# Altium schematic sheet jjfly_2.SchDoc
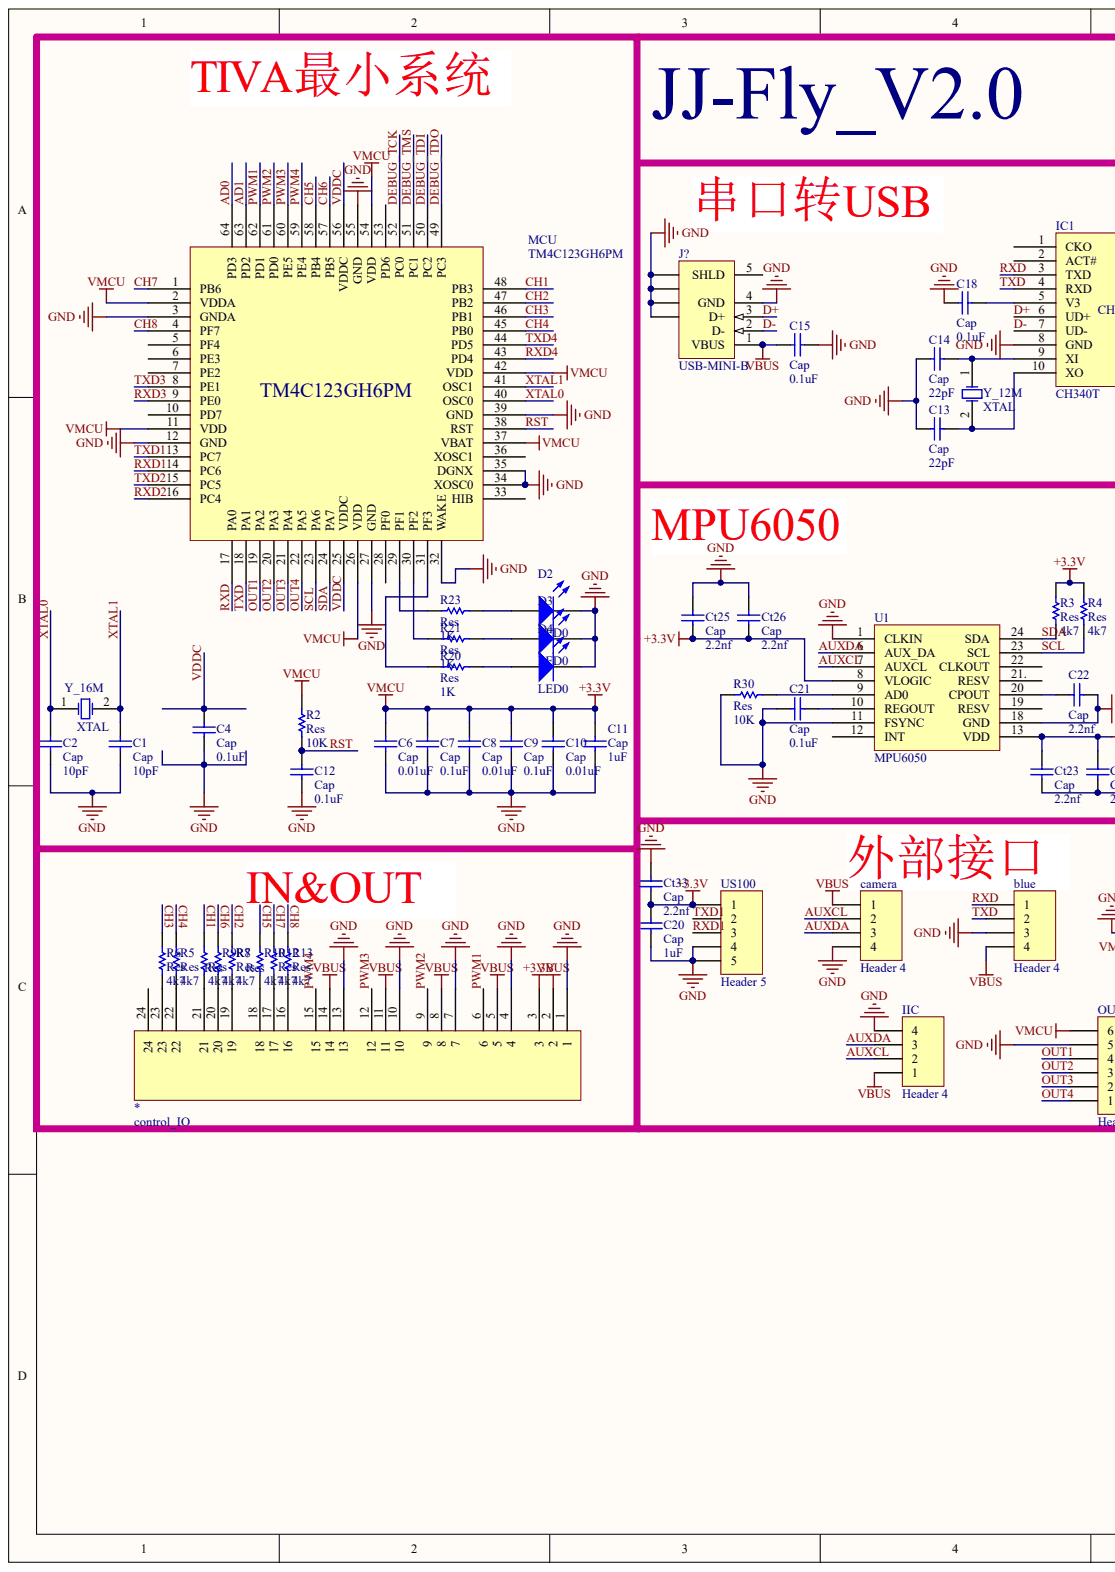
[diagram p0: jjfly_2.SchDoc, left]
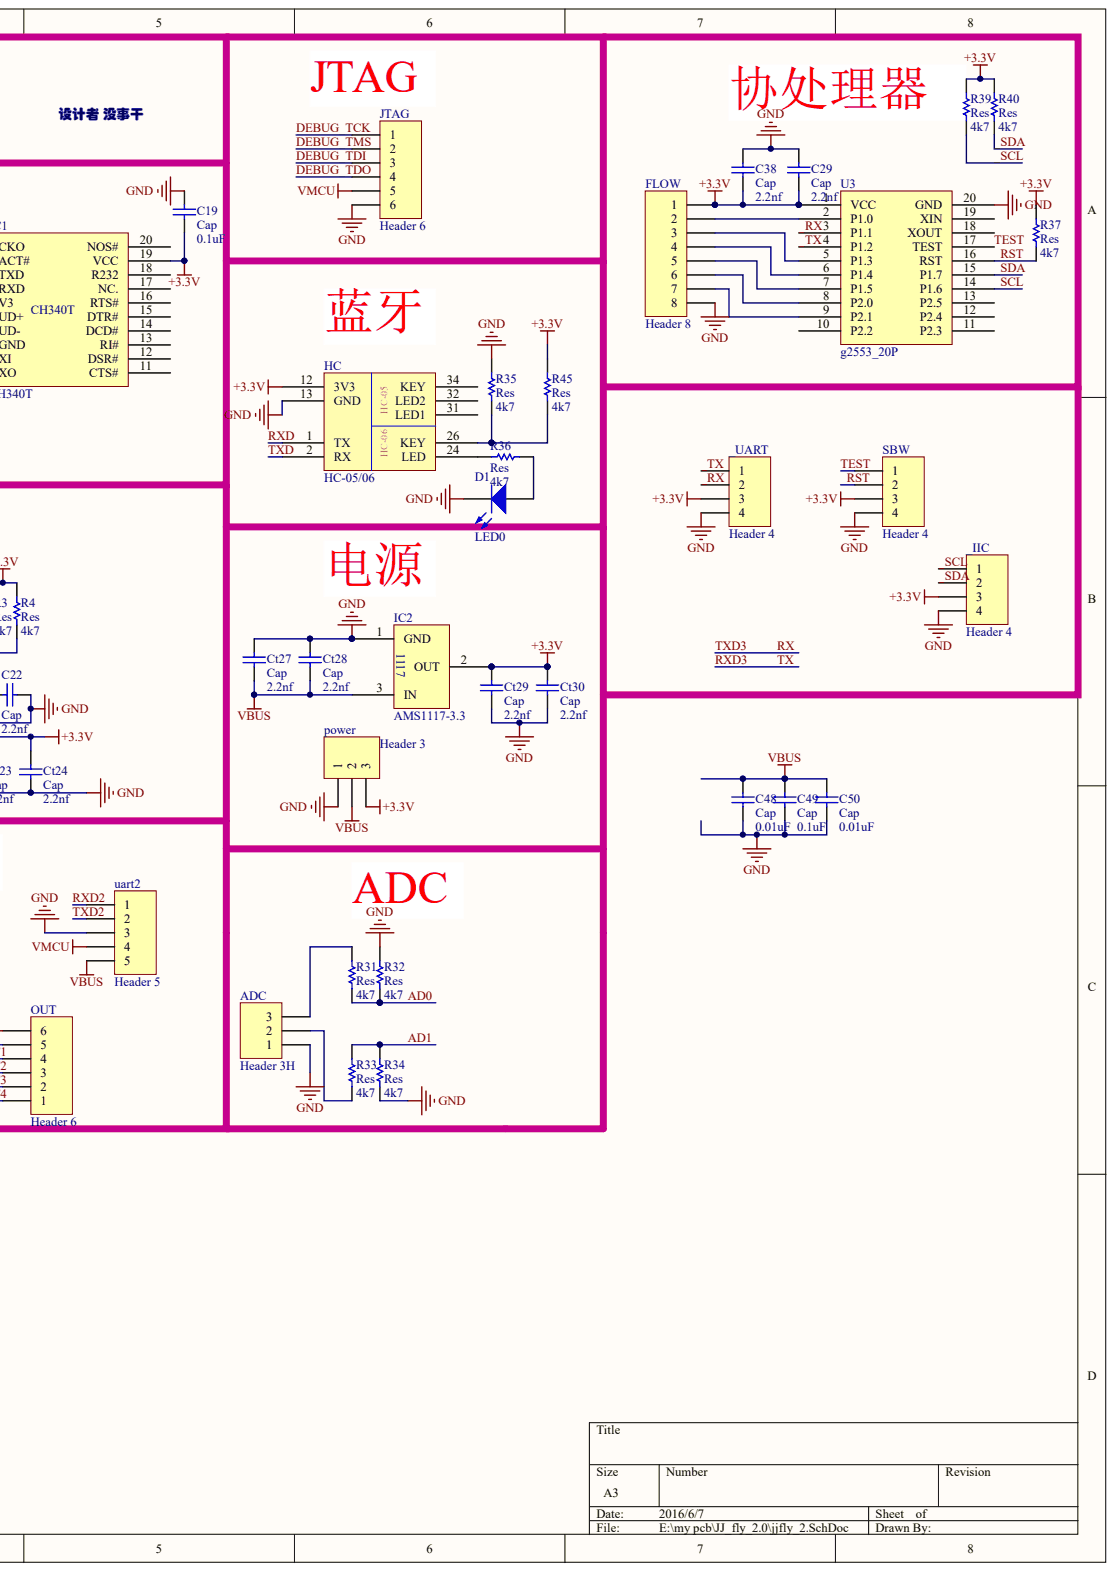
[diagram p1: jjfly_2.SchDoc, right]

## Parts
*  control_IO
_IIC  Header 4
_UART  Header 4
ADC  Header 3H
blue  Header 4
C1  Cap  value 10pF
C2  Cap  value 10pF
C4  Cap  value 0.1uF
C6  Cap  value 0.01uF
C7  Cap  value 0.1uF
C8  Cap  value 0.01uF
C9  Cap  value 0.1uF
C10  Cap  value 0.01uF
C11  Cap  value 1uF
C12  Cap  value 0.1uF
C13  Cap  value 22pF
C14  Cap  value 22pF
C15  Cap  value 0.1uF
C18  Cap  value 0.1uF
C19  Cap  value 0.1uF
C20  Cap  value 1uF
C21  Cap  value 0.1uF
C22  Cap  value 2.2nf
C29  Cap  value 2.2nf
C38  Cap  value 2.2nf
C48  Cap  value 0.01uF
C49  Cap  value 0.1uF
C50  Cap  value 0.01uF
camera  Header 4
Ct23  Cap  value 2.2nf
Ct24  Cap  value 2.2nf
Ct25  Cap  value 2.2nf
Ct26  Cap  value 2.2nf
Ct27  Cap  value 2.2nf
Ct28  Cap  value 2.2nf
Ct29  Cap  value 2.2nf
Ct30  Cap  value 2.2nf
Ct33  Cap  value 2.2nf
D1  LED0
D2  LED0
D3  LED0
D4  LED0
FLOW  Header 8
HC  HC-05/06
IC1  CH340T
IC2  AMS1117-3.3
IIC  Header 4
J?  USB-MINI-B
JTAG  Header 6
MCU  TM4C123GH6PM
OUT  Header 6
power  Header 3
R2  Res  value 10K
R3  Res  value 4k7
R4  Res  value 4k7
R5  Res  value 4k7
R6  Res  value 4k7
R7  Res  value 4k7
R8  Res  value 4k7
R9  Res  value 4k7
R10  Res  value 4k7
R12  Res  value 4k7
R13  Res  value 4k7
R20  Res  value 1K
R21  Res  value 1K
R23  Res  value 1K
R30  Res  value 10K
R31  Res  value 4k7
R32  Res  value 4k7
R33  Res  value 4k7
R34  Res  value 4k7
R35  Res  value 4k7
R36  Res  value 4k7
R37  Res  value 4k7
R39  Res  value 4k7
R40  Res  value 4k7
R45  Res  value 4k7
SBW  Header 4
U1  MPU6050
U3  g2553_20P
uart2  Header 5
US100  Header 5
Y_12M  XTAL
Y_16M  XTAL

## Nets
+3.3V: *.3 _IIC.3 _UART.3 C6.2 C7.2 C8.2 C9.2 C10.2 C11.2 C19.1 C20.2 C29.1 C38.1 Ct23.2 Ct24.2 Ct25.1 Ct26.1 Ct29.2 Ct30.2 Ct33.1 FLOW.1 HC.12(3V3) IC1.19(VCC) IC2.2 JTAG.5 MCU.2(VDDA) MCU.11(VDD) MCU.26(VDD) MCU.37(VBAT) MCU.42(VDD) MCU.54(VDD) OUT.6 power.3 R2.2 R3.2 R4.2 R37.1 R39.2 R40.2 R45.1 SBW.3 U1.8(VLOGIC) U1.13(VDD) U3.1(VCC) uart2.4 US100.1
GND: *.1 *.4 *.7 *.10 *.13 _IIC.4 _UART.4 ADC.1 blue.3 C1.1 C2.1 C4.1 C6.1 C7.1 C8.1 C9.1 C10.1 C11.1 C12.1 C13.2 C14.2 C15.1 C18.2 C19.2 C20.1 C21.1 C22.2 C29.2 C38.2 C48.1 C49.1 C50.1 camera.4 Ct23.1 Ct24.1 Ct25.2 Ct26.2 Ct27.2 Ct28.2 Ct29.1 Ct30.1 Ct33.2 D1.2 D2.2 D3.2 D4.2 FLOW.8 HC.13(GND) IC1.8(GND) IC2.1 IIC.4 J?.4(GND) J?.6 J?.7 J?.8 J?.9 JTAG.6 MCU.3(GNDA) MCU.12(GND) MCU.27(GND) MCU.32(WAKE) MCU.34(XOSC0) MCU.35(DGNX) MCU.39(GND) MCU.55(GND) OUT.5 power.1 R30.2 R32.1 R34.2 R35.1 SBW.4 U1.1(CLKIN) U1.11(FSYNC) U1.18(GND) U3.20(GND) uart2.3 US100.4 US100.5
VBUS: *.2 *.5 *.8 *.11 *.14 blue.4 C15.2 C48.2 C49.2 C50.2 camera.1 Ct27.1 Ct28.1 IC2.3 IIC.1 J?.1(VBUS) power.2 uart2.5
_RST: R37.2 SBW.2 U3.16(RST)
_RX: _UART.2 MCU.8(PE1) U3.3(P1.1)
_SCL: _IIC.1 R39.1 U3.14(P1.6)
_SDA: _IIC.2 R40.1 U3.15(P1.7)
_TX: _UART.1 MCU.9(PE0) U3.4(P1.2)
AD0: MCU.64(PD3) R31.2 R32.2
AD1: MCU.63(PD2) R33.1 R34.1
AUXCL: camera.2 IIC.2 U1.7(AUXCL)
AUXDA: camera.3 IIC.3 U1.6(AUX_DA)
CH1: MCU.48(PB3) R8.2
CH2: MCU.47(PB2) R7.2
CH3: MCU.46(PB1) R6.2
CH4: MCU.45(PB0) R5.2
CH5: MCU.58(PB4) R10.2
CH6: MCU.57(PB5) R9.2
CH7: MCU.1(PB6) R12.2
CH8: MCU.4(PF7) R13.2
D+: IC1.6(UD+) J?.3(D+)
D-: IC1.7(UD-) J?.2(D-)
DEBUG_TCK: JTAG.1 MCU.52(PC0)
DEBUG_TDI: JTAG.3 MCU.50(PC2)
DEBUG_TDO: JTAG.4 MCU.49(PC3)
DEBUG_TMS: JTAG.2 MCU.51(PC1)
OUT1: MCU.19(PA2) OUT.4
OUT2: MCU.20(PA3) OUT.3
OUT3: MCU.21(PA4) OUT.2
OUT4: MCU.22(PA5) OUT.1
PWM1: *.6 MCU.62(PD1)
PWM2: *.9 MCU.61(PD0)
PWM3: *.12 MCU.60(PE5)
PWM4: *.15 MCU.59(PE4)
RST: C12.2 MCU.38(RST) R2.1
RXD: blue.1 HC.1(TX) IC1.3(TXD) MCU.17(PA0)
RXD1: MCU.14(PC6) US100.3
RXD2: MCU.16(PC4) uart2.1
RXD4: MCU.43(PD4)
SCL: MCU.23(PA6) R4.1 U1.23(SCL)
SDA: MCU.24(PA7) R3.1 U1.24(SDA)
TEST: SBW.1 U3.17(TEST)
TXD: blue.2 HC.2(RX) IC1.4(RXD) MCU.18(PA1)
TXD1: MCU.13(PC7) US100.2
TXD2: MCU.15(PC5) uart2.2
TXD4: MCU.44(PD5)
VDDC: C4.2 MCU.25(VDDC) MCU.56(VDDC)
XTAL0: C2.2 MCU.40(OSC0) Y_16M.1
XTAL1: C1.2 MCU.41(OSC1) Y_16M.2
Net*_16: *.16 R13.1
Net*_17: *.17 R12.1
Net*_18: *.18 R10.1
Net*_19: *.19 R7.1
Net*_20: *.20 R9.1
Net*_21: *.21 R8.1
Net*_22: *.22 R5.1
Net*_23: *.23 R6.1
NetADC_2: ADC.2 R33.2
NetADC_3: ADC.3 R31.1
NetC13_1: C13.1 IC1.10(XO) Y_12M.2
NetC14_1: C14.1 IC1.9(XI) Y_12M.1
NetC18_1: C18.1 IC1.5(V3)
NetC21_2: C21.2 U1.10(REGOUT)
NetC22_1: C22.1 U1.20(CPOUT)
NetD1_1: D1.1 R36.2
NetD2_1: D2.1 R23.2
NetD3_1: D3.1 R21.2
NetD4_1: D4.1 R20.2
NetFLOW_2: FLOW.2 U3.2(P1.0)
NetFLOW_3: FLOW.3 U3.5(P1.3)
NetFLOW_4: FLOW.4 U3.6(P1.4)
NetFLOW_5: FLOW.5 U3.7(P1.5)
NetFLOW_6: FLOW.6 U3.8(P2.0)
NetFLOW_7: FLOW.7 U3.9(P2.1)
NetHC_24: HC.24(LED) R36.1
NetHC_26: HC.26(KEY) R35.2 R45.2
NetMCU_29: MCU.29(PF1) R23.1
NetMCU_30: MCU.30(PF2) R21.1
NetMCU_31: MCU.31(PF3) R20.1
NetR30_1: R30.1 U1.9(AD0)

Not connected: * 24; HC 31(LED1) 32(LED2) 34(KEY); IC1 1(CKO) 2(ACT#) 11(CTS#) 12(DSR#) 13(RI#) 14(DCD#) 15(DTR#) 16(RTS#) 17(NC.) 18(R232) 20(NOS#); J? 5(SHLD); MCU 5(PF4) 6(PE3) 7(PE2) 10(PD7) 28(PF0) 33(HIB) 36(XOSC1) 53(PD6); U1 12(INT) 19(RESV) 21.(RESV) 22(CLKOUT); U3 10(P2.2) 11(P2.3) 12(P2.4) 13(P2.5) 18(XOUT) 19(XIN)

## Library data of the parts
C14 = (no symbol name): Value: 22pF; Published: 8-Jun-2000; LatestRevisionDate: 29-May-2009; LatestRevisionNote: IPC-7351 Footprint Added.; PackageReference: C1206; PackageDescription: Chip Capacitor; Publisher: Altium Limited
C18 = (no symbol name): Value: 0.1uF; Published: 8-Jun-2000; LatestRevisionDate: 29-May-2009; LatestRevisionNote: IPC-7351 Footprint Added.; PackageReference: C1206; PackageDescription: Chip Capacitor; Publisher: Altium Limited
J? = (no symbol name): ContactMaterial: Phosphor Bronze; ContactPlating: Gold (30) over Nickel; PCB_Layout: Complies with manufacturer's recommendation.; DrillSize: Corresponds to nominal value.; PanelGround: Without; Published: 15-Jul-2004; DatasheetDocument: 15-Nov-2002; PackageDocument: 15-Nov-2002; PackageReference: 440478; HousingMaterial: Thermoplastic; ComponentLink1Description: Manufacturer Link; ComponentLink1URL: http://www.tycoelectronics.com/; ComponentLink2Description: Packaging Specification; ComponentLink2URL: http://ecommas.tycoelectronics.com/commerce/DocumentDelivery/DDEController?Action=showdoc&DocId=Customer+Drawing%7F440478%7FB%7Fpdf%7FEnglish%7FC_440478_B.pdf; Publisher: Altium Limited
R23 = (no symbol name): Value: 1K; Published: 8-Jun-2000; LatestRevisionDate: 17-Jul-2002; LatestRevisionNote: Re-released for DXP Platform.; PackageReference: AXIAL-0.5; Publisher: Altium Limited
R36 = (no symbol name): Value: 4k7; Published: 8-Jun-2000; LatestRevisionDate: 17-Jul-2002; LatestRevisionNote: Re-released for DXP Platform.; PackageReference: AXIAL-0.5; Publisher: Altium Limited
* _IIC _UART ADC blue C1 C2 C4 C6-C13 C15 C19-C22 C29 C38 C48-C50 camera Ct23-Ct30 Ct33 D1-D4 FLOW HC IC1 IC2 IIC JTAG MCU OUT power R2-R10 R12 R13 R20 R21 R30-R35 R37 R39 R40 R45 SBW U1 U3 uart2 US100 Y_12M Y_16M = (no symbol name)
  _IIC _UART ADC blue camera FLOW IIC JTAG OUT power SBW uart2 US100: LatestRevisionDate: 17-Jul-2002; LatestRevisionNote: Re-released for DXP Platform.
  C1 C2 C4 C6-C13 C15 C19-C22 C29 C38 C48-C50 Ct23-Ct30 Ct33: Published: 8-Jun-2000; LatestRevisionDate: 29-May-2009; LatestRevisionNote: IPC-7351 Footprint Added.; PackageReference: C1206; PackageDescription: Chip Capacitor
  D1-D4: Published: 8-Jun-2000; LatestRevisionDate: 08-Jul-2005; LatestRevisionNote: Mech Layer 1 removed.; PackageReference: LED-1
  R2-R10 R12 R13 R20 R21 R30-R35 R37 R39 R40 R45: Published: 8-Jun-2000; LatestRevisionDate: 17-Jul-2002; LatestRevisionNote: Re-released for DXP Platform.; PackageReference: AXIAL-0.5
  Y_12M Y_16M: Published: 8-Jun-2000; LatestRevisionDate: 17-Jul-2002; LatestRevisionNote: Re-released for DXP Platform.; PackageReference: R38
  _IIC _UART ADC blue C1 C2 C4 C6-C13 C15 C19-C22 C29 C38 C48-C50 camera Ct23-Ct30 Ct33 D1-D4 FLOW IIC JTAG OUT power R2-R10 R12 R13 R20 R21 R30-R35 R37 R39 R40 R45 SBW uart2 US100 Y_12M Y_16M: Publisher: Altium Limited
